# Altium schematic sheet TEMPLATE_Circuit.SchDoc(TEMPLATE_Circuit)
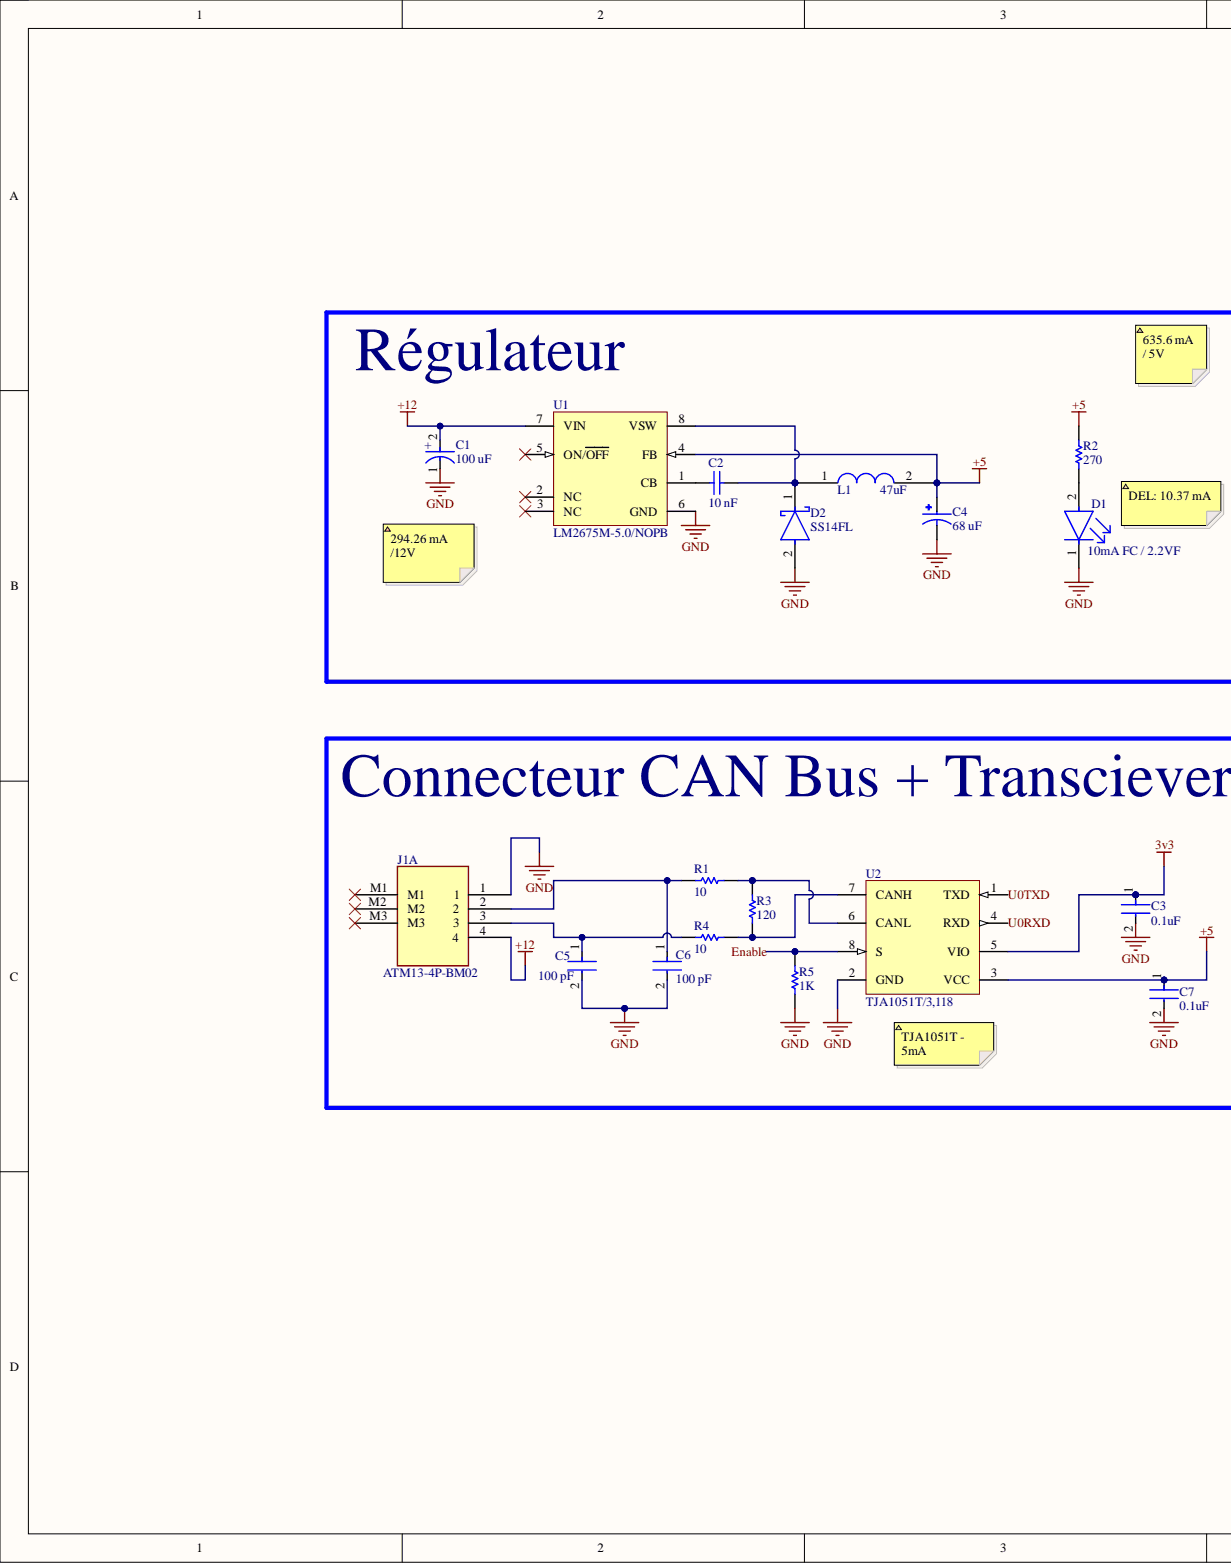
[diagram p0: TEMPLATE_Circuit.SchDoc, left]
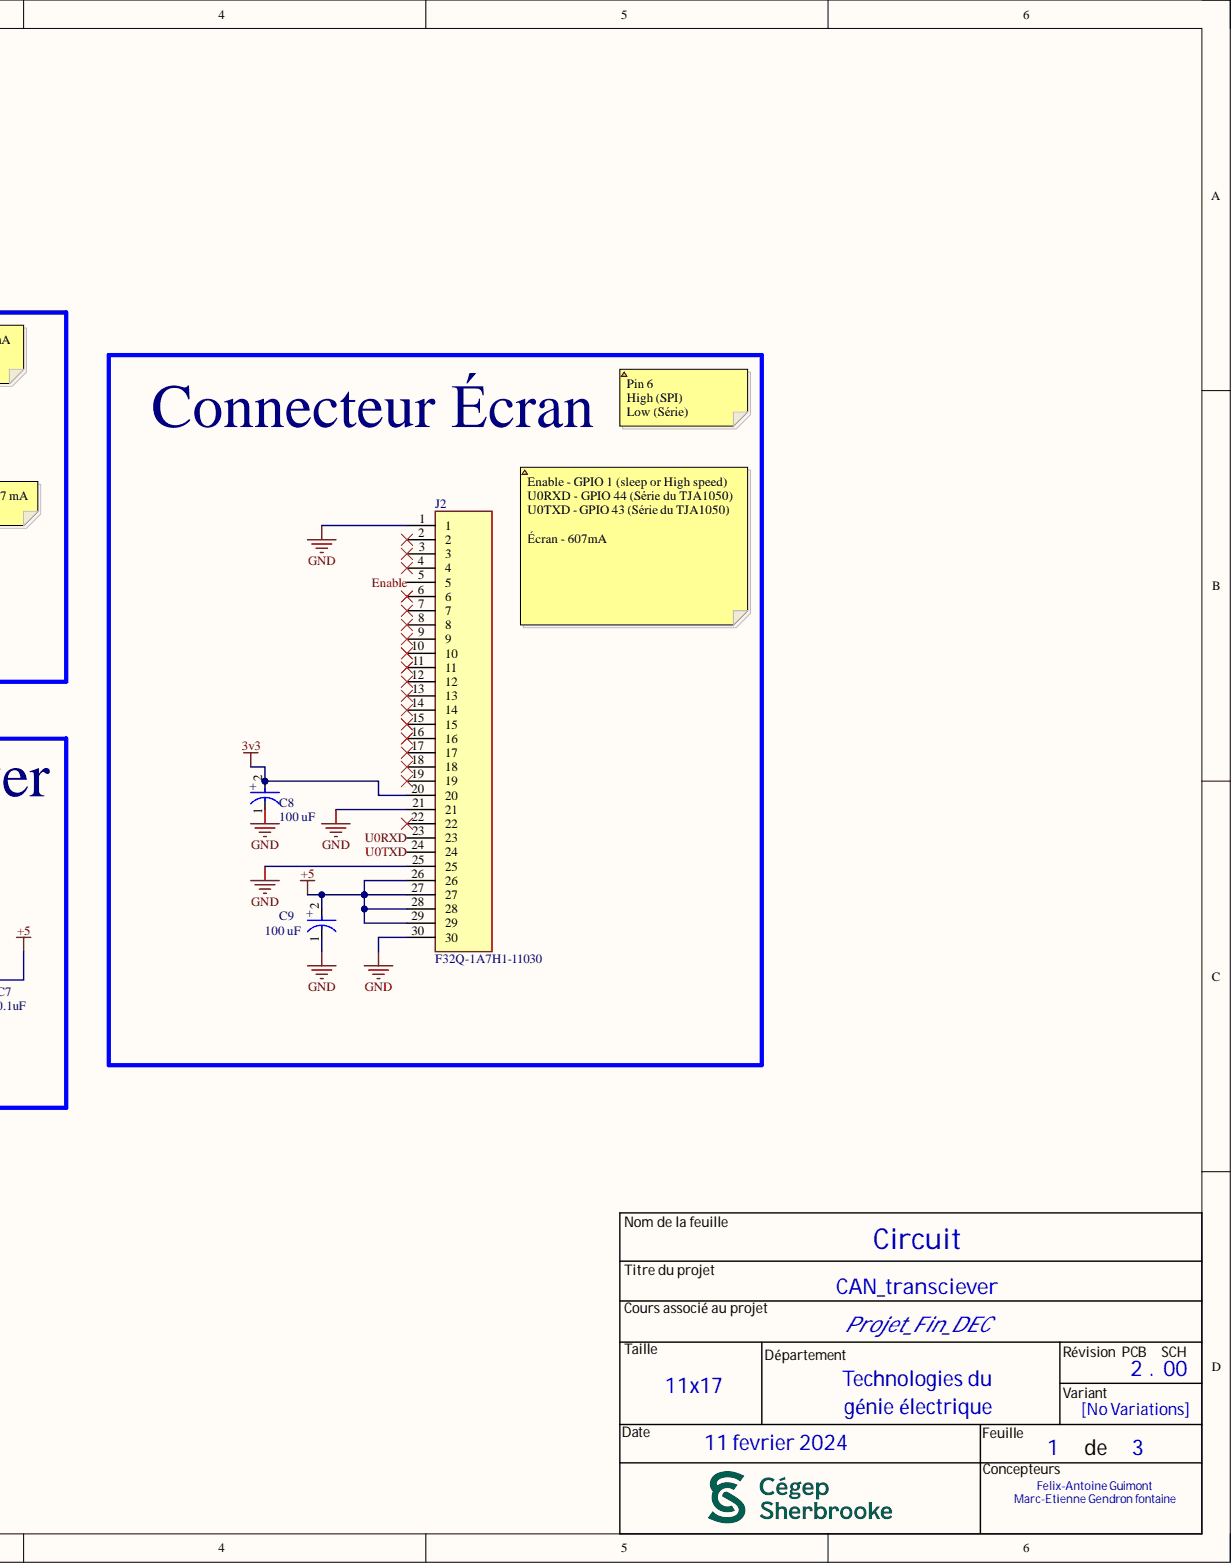
[diagram p1: TEMPLATE_Circuit.SchDoc, right]

## Parts
C1  100 uF  [FP-FKF-MFG]
C2  10 nF  [CAPC1608X90X35ML10T15]
C3  0.1uF  [FP-0805-L_2_01_0_2-W_1_25-IPC_B]
C4  68 uF  [CAP_EEEFN1H680XP]
C5  100 pF  [FP-CC0805-0_1-0_7-IPC_A]
C6  100 pF  [FP-CC0805-0_1-0_7-IPC_A]
C7  0.1uF  [FP-0805-L_2_01_0_2-W_1_25-IPC_B]
C8  100 uF  [FP-FKF-MFG]
C9  100 uF  [FP-FKF-MFG]
D1  10mA FC / 2.2VF  [FP-SML-210-MFG]
D2  SS14FL  [FP-425AD-MFG]
J1  ATM13-4P-BM02  [ATM134PBM02]  (part A)
J2  F32Q-1A7H1-11030  [AMPHENOL_F32Q-1A7H1-11030]
L1  47uF  [NPI31W470MTRF]
R1  10  [RESC2013X50X30NL20T20]
R2  270  [RESC2013X60X40NL20T25]
R3  120  [RESC2013X60X40ML20T25]
R4  10  [RESC2013X50X30NL20T20]
R5  1K  [RESC2013X60X35ML10T20]
U1  LM2675M-5.0/NOPB  [D0008A_L]
U2  TJA1051T/3,118  [NXP-SOIC-8_L]

## Nets
3v3: C3.1 C8.2 J2.20 U2.5(VIO)
+5: C4.1 C7.1 C9.2 J2.26 J2.27 J2.28 J2.29 L1.2 R2.2 U1.4(FB) U2.3(VCC)
+12: C1.2 J1.4 U1.7(VIN)
GND: C1.1 C3.2 C4.2 C5.2 C6.2 C7.2 C8.1 C9.1 D1.1 D2.2 J1.1 J2.1 J2.21 J2.25 J2.30 R5.1 U1.6(GND) U2.2(GND)
Enable: J2.5 R5.2 U2.8(S)
U0RXD: J2.23 U2.4(RXD)
U0TXD: J2.24 U2.1(TXD)
NetC2_1: C2.1 U1.1(CB)
NetC2_2: C2.2 D2.1 L1.1 U1.8(VSW)
NetC5_1: C5.1 J1.3 R4.1
NetC6_1: C6.1 J1.2 R1.1
NetD1_2: D1.2 R2.1
NetR1_2: R1.2 R3.2 U2.6(CANL)
NetR3_1: R3.1 R4.2 U2.7(CANH)

Not connected: J1 M1 M2 M3; J2 2 3 4 6 7 8 9 10 11 12 13 14 15 16 17 18 19 22; U1 2(NC) 3(NC) 5(ON/OFF)

## Library data of the parts
C1 C8 C9 = CMP-2000-06208-3: CAP ALUM 100UF 20% 50V SMD; Dielectric Material: Aluminium; ESR (Equivalent Series Resistance): 340mR; Leakage Current: 3uA; Life (Hours): 2000Hour; Ripple Current: 350mA; Capacitance: 100uF; Case/Package: Radial; Component Kind: Standard; Construction: Cylindrical; Contact Plating: Tin; Diameter: 8mm; Height: 10.2mm; Height - Seated (Max): 0.402inch; Impedance: 340mR; Lead Free: Lead Free; Length: 10.2mm; Library Name: Altium Content Vault; Material: Aluminium; Max Operating Temperature: 105°C; Min Operating Temperature: -55°C; Mount: Surface Mount; Number of Pins: 2; Package Quantity: 1; Packaging: Tape and Reel; Radiation Hardening: No; REACH SVHC: No SVHC; Ripple Current (AC): 350mA; RoHS Compliant: Yes; Series: FK; Termination: SMD/SMT; Tolerance: 20%; Voltage: 50V; Voltage Rating: 50V; Voltage Rating (DC): 50V; Width: 10mm
C2 = CMP-2100-03642-1: Chip Capacitor, 10 nF, +/- 10%, 50 V, -55 to 125 degC, 0603 (1608 Metric), RoHS, Tape and Reel; Manufacturer: Murata; Capacitance: 10nF; Dielectric: X7R; Dielectric Material: Ceramic; Insulation Resistance: 10GR; Voltage: 50V; Case Code (Imperial): 0603; Case Code (Metric): 1608; Case/Package: 0603; Component Kind: Standard; Datasheet URL: http://search.murata.co.jp/Ceramy/image/img/A01X/G101/ENG/GRM188R71H103KA01-01.pdf; Datasheet Version: 42401.0; Depth: 0.8mm; Height: 0.8mm; Lead Free: LeadFree; Length: 1.6mm; Library Name: Altium Content Vault; Manufacturer URL: http://www.murata.com/; Material: Ceramic; Max Operating Temperature: 125degC; Min Operating Temperature: -55degC; Mount: SurfaceMount; Number of Pins: 2; Package Description: 2-Pin Surface Mount Device, Body 1.6 x 0.8 mm; Package Quantity: 1; Package Reference: 0603; Packaging: TapeandReel; REACH SVHC: Unknown; RoHSCompliant: True; Termination: SMD/SMT; Thickness: 0.035inch; Tolerance: 10%; Voltage Rating: 50V; Voltage Rating (DC): 50V; Width: 0.031inch
C3 C7 = CMP-2007-04172-2: Automotive Ceramic Capacitor, 0805, 100nF, 10%, X7R, 15%, 100V; Capacitance: 100nF; Case Code (Imperial): 0805; Case Code (Metric): 2012; Case/Package: 0805; Component Kind: Standard; Construction: Flat; Depth: 1.25mm; Dielectric: X7R; Dielectric Material: Ceramic; Height: 1.4mm; Lead Free: Lead Free; Length: 2.01mm; Library Name: Altium Content Vault; Max Operating Temperature: 125°C; Min Operating Temperature: -55°C; Mount: Surface Mount; Number of Pins: 2; Package Quantity: 1; Packaging: Tape and Reel; Radiation Hardening: No; Ratings: AEC-Q200; REACH SVHC: No SVHC; RoHS Compliant: Yes; Series: -; Termination: SMD/SMT; Thickness: 0.055inch; Tolerance: 10%; Voltage: 100V; Voltage Rating: 100V; Voltage Rating (DC): 100V; Width: 0.049inch
C4 = EEEFN1H680XP: MF: {'manufacturer': u'Panasonic Electronic Components'}; Availability: Unavailable; Component Kind: Standard; Library Name: EEEFN1H680XP.SchLib; MP: EEEFN1H680XP; Package: None; Price: None
C5 C6 = CMP-03422-001508-1: CAP CER 100PF 50V C0G/NPO 0805; Dielectric: C0G; Dielectric Material: Ceramic; Insulation Resistance: 100GR; Temperature Coefficient: 30ppm/°C; Tolerance: 5%; Capacitance: 100pF; Case Code (Imperial): 0805; Case Code (Metric): 2012; Case/Package: 0805; Component Kind: Standard; Depth: 1.25mm; Height: 0.6mm; Lead Free: Lead Free; Length: 2mm; Library Name: Altium Content Vault; Max Operating Temperature: 125°C; Min Operating Temperature: -55°C; Mount: Surface Mount; Number of Pins: 2; Package Quantity: 1; Packaging: Tape and Reel; Radiation Hardening: No; REACH SVHC: No SVHC; Resistor Type: Ceramic; RoHS Compliant: Yes; Series: CC; Termination: SMD/SMT; Thickness: 0.024inch; Voltage: 50V; Voltage Rating: 50V; Voltage Rating (DC): 50V; Width: 1.25mm
D1 = CMP-08952-000013-1: LED GREEN CLEAR 0805 SMD; Manufacturer: ROHM; Manufacturer Part Number: SML-210MTT86; Forward Current: 20mA; Forward Voltage: 2.2V; Led Orientation: Top View; Luminous Intensity: 16mcd; Peak Emmision Wavelength: 573nm; Power Dissipation: 70mW; Reverse Voltage: 4V; Wavelength: 570nm; Automotive: No; Category: Diode; Colour: Green; Component Kind: Standard; ComponentLink2Description: Datasheet; ComponentLink2URL: https://www.mouser.in/ds/2/348/sml-21-313092.pdf; Device Class L1: Optoelectronics; Device Class L2: LEDs; Device Class L3: unset; Digikey Description: LED GREEN CLEAR 0805 SMD; Height: 0.90mm; Ipc Land Pattern Name: DIOCP200125X25; Lead Free: Yes; Library Name: Altium Content Vault; Mouser Description: Standard LEDs - SMD GREEN SMD TRANS LENS; Octopart: https://octopart.com/sml-210mtt86-rohm-550711; Package: SML-210; RoHS: TRUE; Temperature: -30°C to +85°C; Temperature Range High: +85°C; Temperature Range Low: -30°C
D2 = CMP-07162-000013-1: DIODE SCHOTTKY 40V 1A SOD123F; Manufacturer: ON Semiconductor; Capacitance: 60pF; Max Junction Temp: +125°C; Max Surge Forward Current: 40A; Peak Reverse Current: 30uA; Value: 60pF; Automotive: No; Category: Diode; Component Kind: Standard; ComponentLink2Description: Datasheet; ComponentLink2URL: https://www.onsemi.com/pub/Collateral/SS14FL-D.PDF; Device Class L1: Discrete Semiconductors; Device Class L2: Diodes; Device Class L3: Schottky Diodes; Digikey Description: DIODE SCHOTTKY 40V 1A SOD123F; Forward Current: 1A; Height: 1.08mm; Lead Free: Yes; Library Name: Altium Content Vault; Manufacturer Part Number: SS14FL; Max Repetitive Reverse Voltage: 40V; Mouser Description: SS14FL Series 40 V 1 A Surface Mount Schottky Barrier Rectifier - SOD-123F; Octopart: https://octopart.com/ss14fl-on+semiconductor-84331601; Package: 425AD; Reverse Voltage: 40V; RoHS: TRUE; Temperature: -55°C to +125°C; Temperature Range High: +125°C; Temperature Range Low: -55°C
J2 = F32Q-1A7H1-11030: Flex Connector, 0.50mm Pitch, Height 2.00mm, Right angle, Slider type, ZIF, Upper contact, 30 position , Without MYLAR; MF: Amphenol ICC / Aorora; Availability: In Stock; Check_prices: https://www.snapeda.com/parts/F32Q-1A7H1-11030/Amphenol/view-part/?ref=eda; Component Kind: Standard; Library Name: F32Q-1A7H1-11030.SchLib; MP: F32Q-1A7H1-11030; Package: None; Price: None; Purchase-URL: https://pricing.snapeda.com/search/part/F32Q-1A7H1-11030/?ref=eda; SnapEDA_Link: https://www.snapeda.com/parts/F32Q-1A7H1-11030/Amphenol/view-part/?ref=snap
L1 = TEMPLATE_Circuit: Component Kind: Standard; Library Name: NPI31W470MTRF.SchLib
R1 R4 = CMP-2001-04915-1: Manufacturer: Vishay Dale; Part Number: CRCW080510R0FKEA; Component Kind: Standard; Library Name: Altium Content Vault
R2 = CMP-2001-00596-1: Manufacturer: Panasonic; Part Number: ERA6AEB151V; Component Kind: Standard; Library Name: Altium Content Vault
R3 = CMP-2001-00588-1: Manufacturer: Panasonic; Part Number: ERA6AEB121V; Component Kind: Standard; Library Name: Altium Content Vault
R5 = CMP-2100-03671-1: Chip Resistor, 1 KOhm, +/- 1%, 125 mW, -55 to 155 degC, 0805 (2012 Metric), RoHS, Tape and Reel; Manufacturer: Yageo; Max Power Dissipation: 125mW; Resistance: 1KOhm; Temperature Coefficient: 100ppm/degreesC; Voltage Rating: 150V; Case Code (Imperial): 0805; Case Code (Metric): 2012; Case/Package: 0805; Component Kind: Standard; Composition: ThickFilm; Datasheet URL: http://www.yageo.com/NewPortal/yageodocoutput?fileName=/pdf/R-Chip/PYu-RC_Group_51_RoHS_L_5.pdf; Datasheet Version: Rev. 5, 10/2016; Depth: 1.25mm; Height: 0.024inch; Lead Free: LeadFree; Length: 0.079inch; Library Name: Altium Content Vault; Manufacturer URL: http://www.yageo.com/; Max Operating Temperature: 155degC; Military Standard: Not; Min Operating Temperature: -55degC; Number of Pins: 2; Number of Terminations: 2; Package Description: 2-Pin Surface Mounted Device, Body 2 x 1.25 mm; Package Quantity: 1; Package Reference: 0805; Packaging: TapeandReel; Power Rating: 125mW; REACH SVHC: Unknown; RoHSCompliant: true; Termination: SMD/SMT; Tolerance: 1%; Voltage Rating (DC): 150V; Width: 0.049inch
U1 = CMP-0069-00699-3: SIMPLE SWITCHER® Power Converter High Efficiency 1A Step-Down Voltage Regulator, 8-pin Narrow SOIC, Pb-Free; Manufacturer: Texas Instruments; PackageTopMarking: NSZXTT*2675*M5.0; PowerWise: N; Code_IPC: SOIC127P600-8; Code_JEDEC: MS-012-AA; Component Kind: Standard; ComponentLink1Description: Datasheet URL; ComponentLink1URL: http://www.ti.com/general/docs/lit/getliterature.tsp?genericPartNumber=LM2675&fileType=pdf; CompType: Switcher; Library Name: Altium Content Vault; PackageDescription: SOIC Narrow, 4.9 x 3.9 x 1.45mm, 8 Lead, 1.27mm Pitch; PackageReference: D0008A; PinCount: 8; RoHS: Y
U2 = CMP-2000-07245-1: High-Speed CAN Transceiver, 3 to 5 V, 8-Pin SOIC (SOT96-1), RoHS, Tape and Reel; Manufacturer: NXP; Data Rate: 1Mbps; DELETED: Compliant; Number of Transceivers: 1; Operating Supply Voltage (Max): 5.5V; Operating Supply Voltage (Min): 4.5V; Operating Supply Voltage (Typ): 5V; Power Down Mode: Normal/Silent; Rad Hardened: No; Standard Supported: ISO11898-2; Supply Current: 70mA; Category: Transceiver; Component Kind: Standard; Datasheet URL: http://www.nxp.com/documents/data_sheet/TJA1051.pdf; Datasheet Version: Rev. 7, 01/2015; Library Name: Altium Content Vault; Manufacturer URL: http://www.nxp.com/; Mounting: SurfaceMount; Mounting Technology: SurfaceMount; Operating Temperature (Max): 125C; Operating Temperature (Min): -40C; Operating Temperature Classification: Automotive; Package Description: 8-Pin Small Outline Integrated Circuit, Body 4.9 x 3.9 mm, Pitch 1.27 mm; Package Reference: SOT96-1; Package Type: SO; Packaging: TapeandReel; Pin Count: 8
J1 = ATM13-4P-BM02: Component Kind: Standard; Library Name: PCB_MCP_Final.SCHLIB
